# Altium schematic sheet ESC2.SchDoc
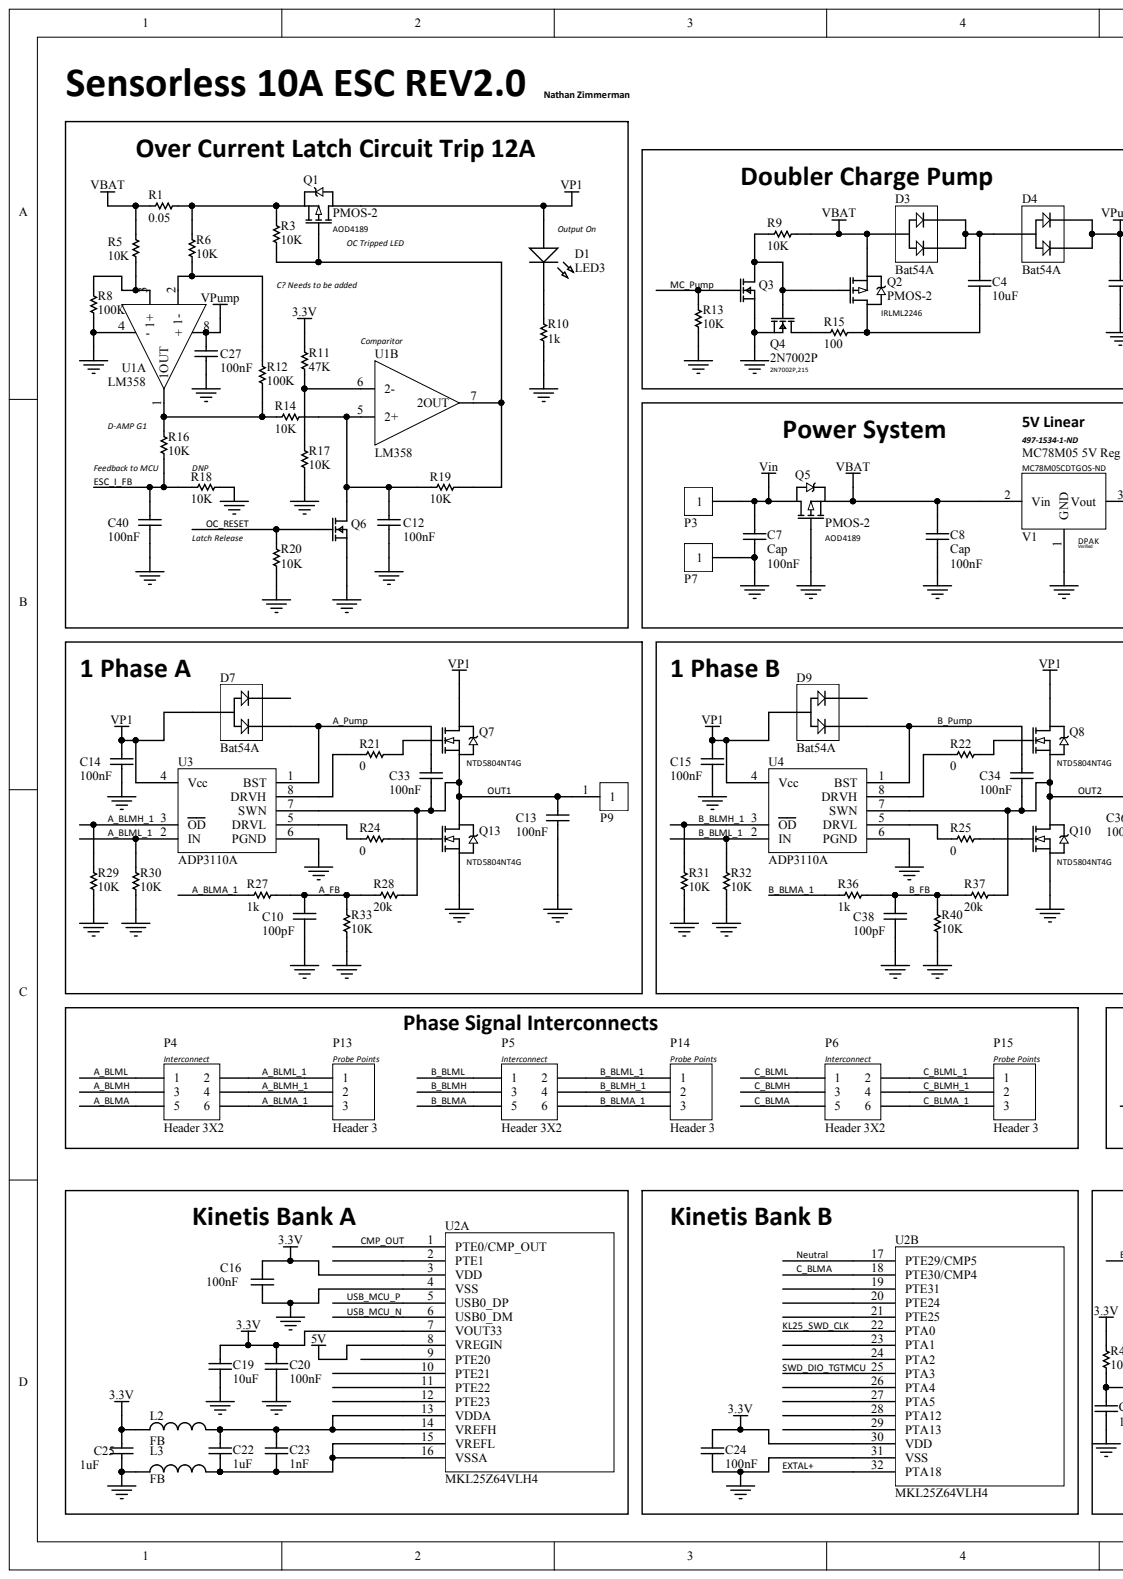
[diagram p0: ESC2.SchDoc, left]
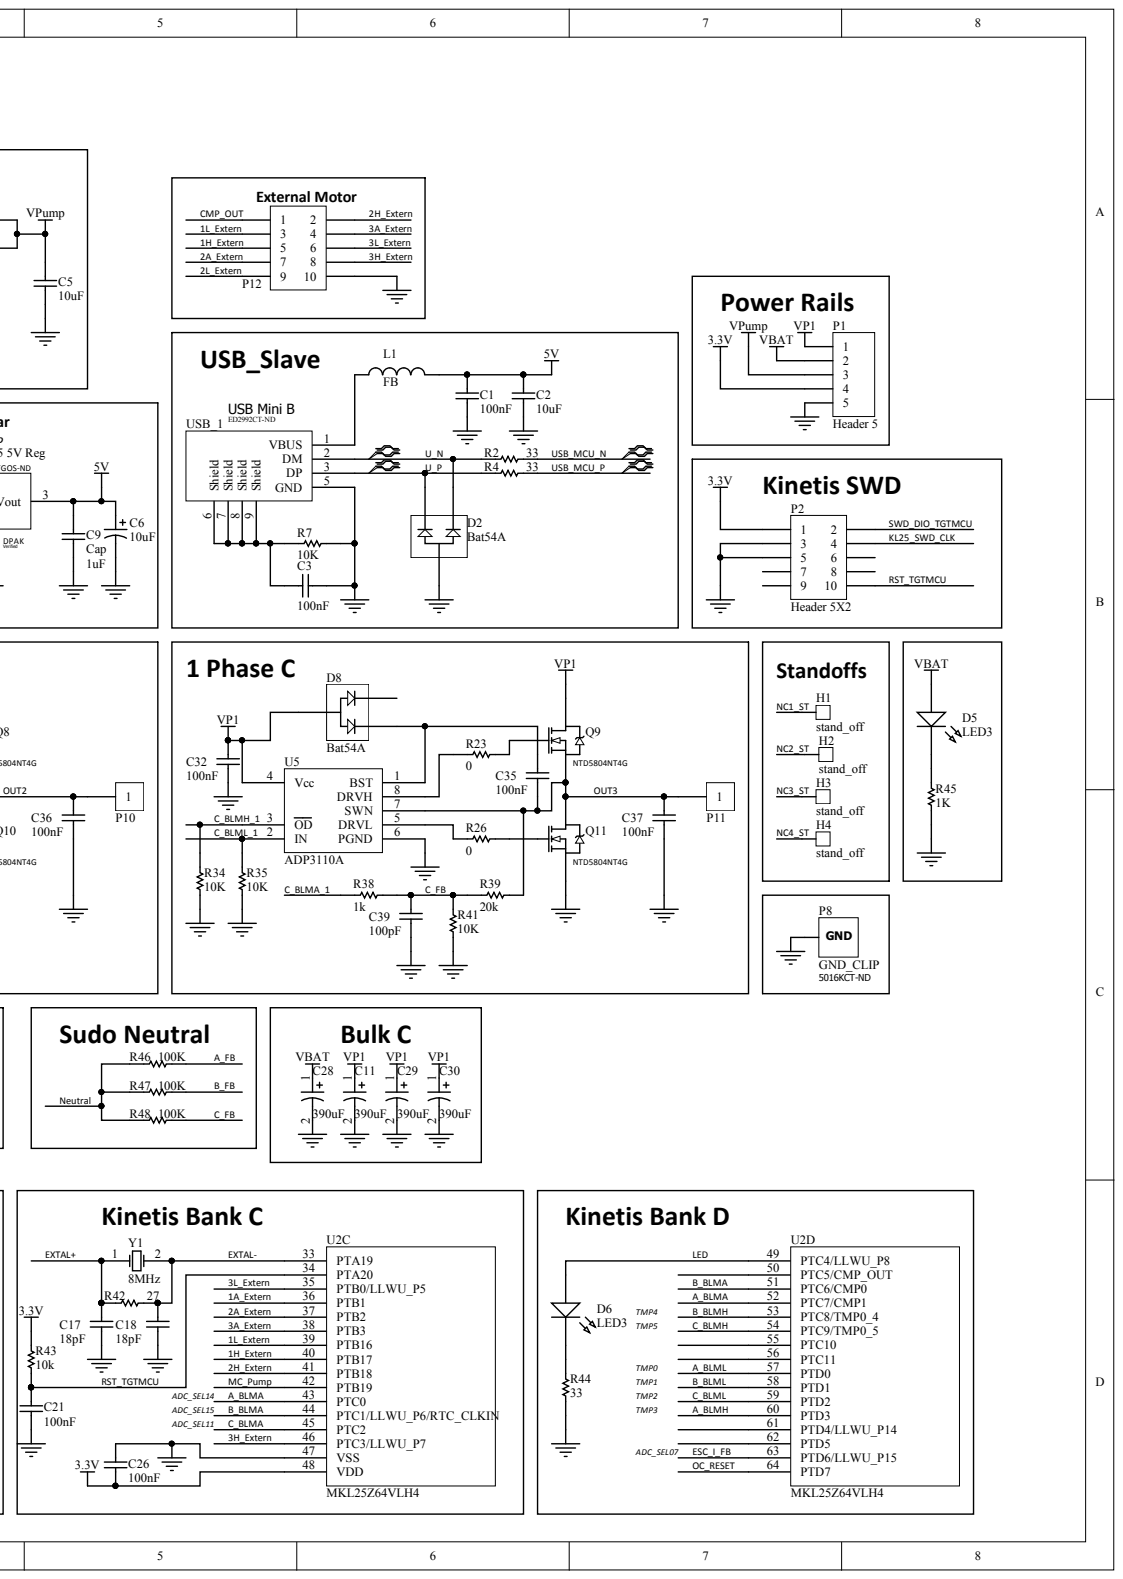
[diagram p1: ESC2.SchDoc, right]

## Parts
C1  value 100nF  [1608[0603]]  (hidden comment: 0805)
C2  value 10uF  [C0805]  (hidden comment: 0805)
C3  value 100nF  [1608[0603]]  (hidden comment: 0805)
C4  value 10uF  [C0805]  (hidden comment: 0805)
C5  value 10uF  [C0805]  (hidden comment: 0805)
C6  value 10uF  [1206_C]  (hidden comment: Cap Pol1)
C7  Cap  value 100nF  [C0805]
C8  Cap  value 100nF  [C0805]
C9  Cap  value 1uF  [C0805]
C10  value 100pF  [1608[0603]]  (hidden comment: 0805)
C11  value 390uF  [EEE-FPV391UAP]  (hidden comment: Cap Pol1)
C12  value 100nF  [1608[0603]]  (hidden comment: 0805)
C13  value 100nF  [1608[0603]]  (hidden comment: 0805)
C14  value 100nF  [1608[0603]]  (hidden comment: 0805)
C15  value 100nF  [1608[0603]]  (hidden comment: 0805)
C16  value 100nF  [1608[0603]]  (hidden comment: 0805)
C17  value 18pF  [1608[0603]]  (hidden comment: 0805)
C18  value 18pF  [1608[0603]]  (hidden comment: 0805)
C19  value 10uF  [C0805]  (hidden comment: 0805)
C20  value 100nF  [1608[0603]]  (hidden comment: 0805)
C21  value 100nF  [1608[0603]]  (hidden comment: 0805)
C22  value 1uF  [1608[0603]]  (hidden comment: 0805)
C23  value 1nF  [1608[0603]]  (hidden comment: 0805)
C24  value 100nF  [1608[0603]]  (hidden comment: 0805)
C25  value 1uF  [1608[0603]]  (hidden comment: 0805)
C26  value 100nF  [1608[0603]]  (hidden comment: 0805)
C27  value 100nF  [1608[0603]]  (hidden comment: 0805)
C28  value 390uF  [EEE-FPV391UAP]  (hidden comment: Cap Pol1)
C29  value 390uF  [EEE-FPV391UAP]  (hidden comment: Cap Pol1)
C30  value 390uF  [EEE-FPV391UAP]  (hidden comment: Cap Pol1)
C32  value 100nF  [1608[0603]]  (hidden comment: 0805)
C33  value 100nF  [1608[0603]]  (hidden comment: 0805)
C34  value 100nF  [1608[0603]]  (hidden comment: 0805)
C35  value 100nF  [1608[0603]]  (hidden comment: 0805)
C36  value 100nF  [1608[0603]]  (hidden comment: 0805)
C37  value 100nF  [1608[0603]]  (hidden comment: 0805)
C38  value 100pF  [1608[0603]]  (hidden comment: 0805)
C39  value 100pF  [1608[0603]]  (hidden comment: 0805)
C40  value 100nF  [1608[0603]]  (hidden comment: 0805)
D1  LED3  [6-0805_L]
D2  Bat54A  [SOT-23B_N]
D3  Bat54A  [SOT-23B_N]
D4  Bat54A  [SOT-23B_N]
D5  LED3  [6-0805_L]
D6  LED3  [6-0805_L]
D7  Bat54A  [SOT-23B_N]
D8  Bat54A  [SOT-23B_N]
D9  Bat54A  [SOT-23B_N]
H1  stand_off  [4-40_screw_hole]
H2  stand_off  [4-40_screw_hole]
H3  stand_off  [4-40_screw_hole]
H4  stand_off  [4-40_screw_hole]
L1  FB  [1608[0603]]
L2  FB  [1608[0603]]
L3  FB  [1608[0603]]
P1  Header 5  [HDR1X5]
P2  Header 5X2  [MHDR2X5]
P3  Header  [8191_K]  (value not drawn)
P4  Header 3X2  [HDR2X3]
P5  Header 3X2  [HDR2X3]
P6  Header 3X2  [HDR2X3]
P7  Header  [8191_K]  (value not drawn)
P8  GND_CLIP  [GND_CLIP]
P9  Header  [8191_K]  (value not drawn)
P10  Header  [8191_K]  (value not drawn)
P11  Header  [8191_K]  (value not drawn)
P12  Header 5X2  [HDR2X5]
P13  Header 3  [HDR1X3]
P14  Header 3  [HDR1X3]
P15  Header 3  [HDR1X3]
Q1  PMOS-2  [TO228P970-3_4AM]
Q2  PMOS-2  [SOT-23_M]
Q3  2N7002P  [SOT-23_M]
Q4  2N7002P  [SOT-23_M]
Q5  PMOS-2  [TO228P970-3_4AM]
Q6  2N7002P  [SOT-23_M]  (value not drawn)
Q7  NMOS-2  [TO228P970-3_4AM]  (value not drawn)
Q8  NMOS-2  [TO228P970-3_4AM]  (value not drawn)
Q9  NMOS-2  [TO228P970-3_4AM]  (value not drawn)
Q10  NMOS-2  [TO228P970-3_4AM]  (value not drawn)
Q11  NMOS-2  [TO228P970-3_4AM]  (value not drawn)
Q13  NMOS-2  [TO228P970-3_4AM]  (value not drawn)
R1  value 0.05  [RESC6432AM]  (hidden comment: Res1)
R2  value 33  [1608[0603]]  (hidden comment: Res1)
R3  value 10K  [1608[0603]]  (hidden comment: Res1)
R4  value 33  [1608[0603]]  (hidden comment: Res1)
R5  value 10K  [1608[0603]]  (hidden comment: Res1)
R6  value 10K  [1608[0603]]  (hidden comment: Res1)
R7  value 10K  [1608[0603]]  (hidden comment: Res1)
R8  value 100K  [1608[0603]]  (hidden comment: Res1)
R9  value 10K  [1608[0603]]  (hidden comment: Res1)
R10  value 1k  [1608[0603]]  (hidden comment: Res1)
R11  value 47K  [1608[0603]]  (hidden comment: Res1)
R12  value 100K  [1608[0603]]  (hidden comment: Res1)
R13  value 10K  [1608[0603]]  (hidden comment: Res1)
R14  value 10K  [1608[0603]]  (hidden comment: Res1)
R15  value 100  [1608[0603]]  (hidden comment: Res1)
R16  value 10K  [1608[0603]]  (hidden comment: Res1)
R17  value 10K  [1608[0603]]  (hidden comment: Res1)
R18  value 10K  [1608[0603]]  (hidden comment: Res1)
R19  value 10K  [1608[0603]]  (hidden comment: Res1)
R20  value 10K  [1608[0603]]  (hidden comment: Res1)
R21  value 0  [1608[0603]]  (hidden comment: Res1)
R22  value 0  [1608[0603]]  (hidden comment: Res1)
R23  value 0  [1608[0603]]  (hidden comment: Res1)
R24  value 0  [1608[0603]]  (hidden comment: Res1)
R25  value 0  [1608[0603]]  (hidden comment: Res1)
R26  value 0  [1608[0603]]  (hidden comment: Res1)
R27  value 1k  [1608[0603]]  (hidden comment: Res1)
R28  value 20k  [1608[0603]]  (hidden comment: Res1)
R29  value 10K  [1608[0603]]  (hidden comment: Res1)
R30  value 10K  [1608[0603]]  (hidden comment: Res1)
R31  value 10K  [1608[0603]]  (hidden comment: Res1)
R32  value 10K  [1608[0603]]  (hidden comment: Res1)
R33  value 10K  [1608[0603]]  (hidden comment: Res1)
R34  value 10K  [1608[0603]]  (hidden comment: Res1)
R35  value 10K  [1608[0603]]  (hidden comment: Res1)
R36  value 1k  [1608[0603]]  (hidden comment: Res1)
R37  value 20k  [1608[0603]]  (hidden comment: Res1)
R38  value 1k  [1608[0603]]  (hidden comment: Res1)
R39  value 20k  [1608[0603]]  (hidden comment: Res1)
R40  value 10K  [1608[0603]]  (hidden comment: Res1)
R41  value 10K  [1608[0603]]  (hidden comment: Res1)
R42  value 27  [1608[0603]]  (hidden comment: Res1)
R43  value 10k  [1608[0603]]  (hidden comment: Res1)
R44  value 33  [1608[0603]]  (hidden comment: Res1)
R45  value 1K  [1608[0603]]  (hidden comment: Res1)
R46  value 100K  [1608[0603]]  (hidden comment: Res1)
R47  value 100K  [1608[0603]]  (hidden comment: Res1)
R48  value 100K  [1608[0603]]  (hidden comment: Res1)
U1  LM358  [SOIC127P600-8BM]  (parts A,B)
U2  MKL25Z64VLH4  [TSQFP50P1200X1200-64M]  (parts A,B,C,D)
U3  ADP3110A  [SOIC127P600-8BM]
U4  ADP3110A  [SOIC127P600-8BM]
U5  ADP3110A  [SOIC127P600-8BM]
USB_1  Digikey: ED2992CT-ND  [USB-M2xFxx]  (hidden comment: USB-M2xFxx)
V1  MC78M05 5V Reg  [TO228P970-3_4AM]
Y1  8MHz  [crystal]

## Nets
3.3V: C16.2 C19.1 C20.1 C24.2 C25.2 C26.1 L2.2 P1.4 P2.1 R11.1 R43.2 U2.3(VDD) U2.7(VOUT33) U2.30(VDD) U2.48(VDD)
5V: C1.1 C2.1 C6.1 C9.2 L1.1 U2.8(VREGIN) V1.3
GND: C1.2 C2.2 C3.2 C5.2 C6.2 C7.1 C8.1 C9.1 C10.1 C11.2 C12.1 C13.1 C14.1 C15.1 C16.1 C17.1 C18.1 C19.2 C20.2 C21.1 C24.1 C25.1 C26.2 C27.1 C28.2 C29.2 C30.2 C32.1 C36.1 C37.1 C38.1 C39.1 C40.1 D2.3 L3.2 P1.5 P2.3 P2.5 P7.1 P8.1 P12.10 Q3.2 Q4.2 Q5.1 Q6.2 Q10.3 Q11.3 Q13.3 R7.1 R8.1 R10.2 R13.2 R17.2 R18.1 R20.2 R29.2 R30.2 R31.2 R32.2 R33.2 R34.2 R35.2 R40.2 R41.2 R44.2 R45.2 U1.4(-) U2.4(VSS) U2.31(VSS) U2.47(VSS) U3.6(PGND) U4.6(PGND) U5.6(PGND) USB_1.5(GND) V1.1
VBAT: C8.2 C28.1 D3.3 D5.1 P1.2 Q2.2 Q5.3 R1.1 R5.1 R9.1 V1.2
VIN: C7.2 P3.1 Q5.4
VP1: C11.1 C14.2 C15.2 C29.1 C30.1 C32.2 D1.1 D7.3 D8.3 D9.3 P1.1 Q1.4 Q7.4 Q8.4 Q9.4 U3.4(Vcc) U4.4(Vcc) U5.4(Vcc)
VPUMP: C5.1 C27.2 D4.1 D4.2 P1.3 U1.8(+)
1A_EXTERN: U2.36(PTB1)
1H_EXTERN: P12.5 U2.40(PTB17)
1L_EXTERN: P12.3 U2.39(PTB16)
2A_EXTERN: P12.7 U2.37(PTB2)
2H_EXTERN: P12.2 U2.41(PTB18)
2L_EXTERN: P12.9
3A_EXTERN: P12.4 U2.38(PTB3)
3H_EXTERN: P12.8 U2.46(PTC3/LLWU_P7)
3L_EXTERN: P12.6 U2.35(PTB0/LLWU_P5)
A_BLMA: P4.5 U2.43(PTC0) U2.52(PTC7/CMP1)
A_BLMA_1: P4.6 P13.3 R27.2
A_BLMH: P4.3 U2.60(PTD3)
A_BLMH_1: P4.4 P13.2 R29.1 U3.3(OD)
A_BLML: P4.1 U2.57(PTD0)
A_BLML_1: P4.2 P13.1 R30.1 U3.2(IN)
A_FB: C10.2 R27.1 R28.2 R33.1 R46.2
A_PUMP: C33.2 D7.1 U3.1(BST)
B_BLMA: P5.5 U2.44 U2.51(PTC6/CMP0)
B_BLMA_1: P5.6 P14.3 R36.2
B_BLMH: P5.3 U2.53(PTC8/TMP0_4)
B_BLMH_1: P5.4 P14.2 R31.1 U4.3(OD)
B_BLML: P5.1 U2.58(PTD1)
B_BLML_1: P5.2 P14.1 R32.1 U4.2(IN)
B_FB: C38.2 R36.1 R37.2 R40.1 R47.2
B_PUMP: C34.2 D9.1 U4.1(BST)
C_BLMA: P6.5 U2.18(PTE30/CMP4) U2.45(PTC2)
C_BLMA_1: P6.6 P15.3 R38.2
C_BLMH: P6.3 U2.54(PTC9/TMP0_5)
C_BLMH_1: P6.4 P15.2 R34.1 U5.3(OD)
C_BLML: P6.1 U2.59(PTD2)
C_BLML_1: P6.2 P15.1 R35.1 U5.2(IN)
C_FB: C39.2 R38.1 R39.2 R41.1 R48.2
CMP_OUT: P12.1 U2.1(PTE0/CMP_OUT)
ESC_I_FB: C40.2 R16.1 R18.2 U2.63(PTD6/LLWU_P15)
EXTAL+: C17.2 R42.1 U2.32(PTA18) Y1.1
EXTAL-: C18.2 R42.2 U2.33(PTA19) Y1.2
KL25_SWD_CLK: P2.4 U2.22(PTA0)
LED: D6.1 U2.49(PTC4/LLWU_P8)
MC_PUMP: Q3.1 R13.1 U2.42(PTB19)
NC1_ST: H1.1
NC2_ST: H2.1
NC3_ST: H3.1
NC4_ST: H4.1
NEUTRAL: R46.1 R47.1 R48.1 U2.17(PTE29/CMP5)
OC_RESET: Q6.1 R20.1 U2.64(PTD7)
OUT1: C13.2 C33.1 P9.1 Q7.3 Q13.4 R28.1 U3.7(SWN)
OUT2: C34.1 C36.2 P10.1 Q8.3 Q10.4 R37.1 U4.7(SWN)
OUT3: C35.1 C37.2 P11.1 Q9.3 Q11.4 R39.1 U5.7(SWN)
RST_TGTMCU: C21.2 P2.10 R43.1 U2.34(PTA20)
SWD_DIO_TGTMCU: P2.2 U2.25(PTA3)
U_N: D2.1 R2.1 USB_1.2(DM)
U_P: D2.2 R4.1 USB_1.3(DP)
USB_MCU_N: R2.2 U2.6(USB0_DM)
USB_MCU_P: R4.2 U2.5(USB0_DP)
NetC3_1: C3.1 R7.2 USB_1.6(Shield) USB_1.7(Shield) USB_1.8(Shield) USB_1.9(Shield)
NetC4_1: C4.1 D3.1 D3.2 D4.3
NetC4_2: C4.2 Q2.3 R15.1
NetC12_2: C12.2 Q6.3 R14.2 R19.1 U1.5(2+)
NetC22_1: C22.1 C23.1 L3.1 U2.15(VREFL) U2.16(VSSA)
NetC22_2: C22.2 C23.2 L2.1 U2.13(VDDA) U2.14(VREFH)
NetC35_2: C35.2 D8.1 U5.1(BST)
NetD1_2: D1.2 R10.1
NetD5_2: D5.2 R45.1
NetD6_2: D6.2 R44.1
NetL1_2: L1.2 USB_1.1(VBUS)
NetQ1_1: Q1.1 R3.2 R19.2 U1.7(2OUT)
NetQ1_3: Q1.3 R1.2 R3.1 R6.2
NetQ2_1: Q2.1 Q3.3 Q4.1 R9.2
NetQ4_3: Q4.3 R15.2
NetQ7_1: Q7.1 R21.1
NetQ8_1: Q8.1 R22.1
NetQ9_1: Q9.1 R23.1
NetQ10_1: Q10.1 R25.1
NetQ11_1: Q11.1 R26.1
NetQ13_1: Q13.1 R24.1
NetR5_2: R5.2 R8.2 U1.3(1+)
NetR6_1: R6.1 R12.2 U1.2(1-)
NetR11_2: R11.2 R17.1 U1.6(2-)
NetR12_1: R12.1 R14.1 R16.2 U1.1(1OUT)
NetR21_2: R21.2 U3.8(DRVH)
NetR22_2: R22.2 U4.8(DRVH)
NetR23_2: R23.2 U5.8(DRVH)
NetR24_2: R24.2 U3.5(DRVL)
NetR25_2: R25.2 U4.5(DRVL)
NetR26_2: R26.2 U5.5(DRVL)

Not connected: D7 2; D8 2; D9 2; P2 6 7 8 9; U2 2(PTE1) 9(PTE20) 10(PTE21) 11(PTE22) 12(PTE23) 19(PTE31) 20(PTE24) 21(PTE25) 23(PTA1) 24(PTA2) 26(PTA4) 27(PTA5) 28(PTA12) 29(PTA13) 50(PTC5/CMP_OUT) 55(PTC10) 56(PTC11) 61(PTD4/LLWU_P14) 62(PTD5)

## Library data of the parts
C1-C5 C10 C12-C27 C32-C40 = Cap Semi: Capacitor (Semiconductor SIM Model); Component Kind: Standard; Library Name: Miscellaneous Devices.IntLib; Signal Integrity: Cap Semi; Simulation: CAP
  C1-C5 C10 C13-C26 C32-C40: Digikey: 311-1361-1-ND; LatestRevisionDate: 29-May-2009; LatestRevisionNote: IPC-7351 Footprint Added.; PackageDescription: Chip Capacitor; PackageReference: C1206; Published: 8-Jun-2000; Publisher: Altium Limited
C6 = Cap Pol1: Polarized Capacitor (Radial); Component Kind: Standard; Library Name: Miscellaneous Devices.SchLib; Signal Integrity: Cap Pol; Simulation: CAP
C7-C9 = Cap: Capacitor; Component Kind: Standard; LatestRevisionDate: 17-Jul-2002; LatestRevisionNote: Re-released for DXP Platform.; Library Name: Miscellaneous Devices.IntLib; PackageDescription: Radial Cap, Thru-Hole; 2 Leads; 0.3 in Pin Spacing; PackageReference: RAD-0.3; Published: 8-Jun-2000; Signal Integrity: Cap; Simulation: CAP; Publisher: Altium Limited
C11 C28-C30 = Cap Pol1: Polarized Capacitor (Radial); Rating: 35V; Component Kind: Standard; Library Name: Miscellaneous Devices.IntLib; Part #: EEE-FPV391UAP; Signal Integrity: Cap Pol
D1 D5 D6 = LED3: Typical BLUE SiC LED; Component Kind: Standard; DatasheetVersion: 16-Apr-2002; LatestRevisionDate: 17-Dec-2003; LatestRevisionNote: PCB Footprint replaced.; Library Name: Miscellaneous Devices.SchLib; PackageDescription: SM LED; 2 C-Bend Leads; Body 3.5 x 2.8 x 1.9 mm, inc leads (LxWxH typ); PackageReference: 3.5X2.8X1.9; PackageVersion: 16-Apr-2002; Published: 8-Jun-2000; Signal Integrity: LED; Simulation: LED3; Publisher: Altium Limited
D2-D4 D7-D9 = Bat45C: Component Kind: Standard; Description: Bat45C; Library Name: GSOT05CGS08.SchLib
L1-L3 = Inductor: Component Kind: Standard; Description: Inductor; Library Name: Miscellaneous Devices.IntLib; Signal Integrity: Inductor; Simulation: INDUCTOR
  L1: : 240-2399-1-ND
  L2 L3: : CIM10U800NC
P1 = Header 5: Header, 5-Pin; Component Kind: Standard; LatestRevisionDate: 17-Jul-2002; LatestRevisionNote: Re-released for DXP Platform.; Library Name: Miscellaneous Connectors.IntLib; Signal Integrity: Connector; Publisher: Altium Limited
P2 P12 = Header 5X2: Header, 5-Pin, Dual row; Component Kind: Standard; LatestRevisionDate: 17-Jul-2002; LatestRevisionNote: Re-released for DXP Platform.; Library Name: Miscellaneous Connectors.IntLib; Signal Integrity: Connector; Publisher: Altium Limited
P3 P7 P9-P11 = Header1h1: Component Kind: Standard; Description: Header; Library Name: Header1.SchLib
P4-P6 = Header 3X2: Header, 3-Pin, Dual row; Component Kind: Standard; LatestRevisionDate: 17-Jul-2002; LatestRevisionNote: Re-released for DXP Platform.; Library Name: Miscellaneous Connectors.IntLib; Signal Integrity: Connector; Publisher: Altium Limited
P13-P15 = Header 3: Header, 3-Pin; Component Kind: Standard; LatestRevisionDate: 17-Jul-2002; LatestRevisionNote: Re-released for DXP Platform.; Library Name: Miscellaneous Connectors.IntLib; Signal Integrity: Connector; Publisher: Altium Limited
Q1 Q2 Q5 = PMOS-2: P-Channel Power MOSFET; Component Kind: Standard; Library Name: Miscellaneous Devices.SchLib
  Q1 Q5: : AOD4189
  Q2: : IRLML2246
Q7-Q11 Q13 = NMOS-2: N-Channel Power MOSFET; : NTD5804NT4G; Component Kind: Standard; Library Name: Miscellaneous Devices.SchLib
R1-R48 = Res1: Resistor; Component Kind: Standard; LatestRevisionDate: 17-Jul-2002; LatestRevisionNote: Re-released for DXP Platform.; Library Name: Miscellaneous Devices.IntLib; PackageDescription: Axial Device, Thru-Hole; 2 Leads; 0.3 in Pin Spacing; PackageReference: AXIAL-0.3; Published: 8-Jun-2000; Signal Integrity: Res; Simulation: RESISTOR; Publisher: Altium Limited
U1 = LM358: LM358 Op Amp; Component Kind: Standard; Library Name: LM358_New.SchLib
U2 = MKL25Z64VLH4: MKL25Z64VLH4 MCU; Component Kind: Standard; Library Name: MKL25Z64VLH4.SchLib
USB_1 = USB-M2xFxx: USB Mini-B for USB-M2xFxx; Component Kind: Standard; Library Name: USB-M2xFxx.SchLib
V1 = MCP1702: MCP1702 5V REG; : 497-1534-1-ND; Component Kind: Standard; Library Name: MCP1702.SchLib
Y1 = XTAL: Crystal Oscillator; : ABLS-8.000MHZ-B4-T; Component Kind: Standard; Library Name: Miscellaneous Devices.SchLib; Simulation: XTAL
H1-H4 = stand_off: Component Kind: Standard; Description: stand_off; Library Name: pcb_standoff.SchLib
P8 = GND_CLIP: : 5016KCT-ND; Component Kind: Standard; Description: GND_CLIP; Library Name: GND_CLIP.SchLib
Q3 Q4 Q6 = 2N7002P: : 2N7002P,215; Component Kind: Standard; Description: 2N7002P; Library Name: 2N7002P215.SchLib
U3-U5 = ADP3110A: Component Kind: Standard; Description: ADP3110A; Library Name: ADP3110A.SchLib
